# Altium schematic sheet audio out.SchDoc("audio out")
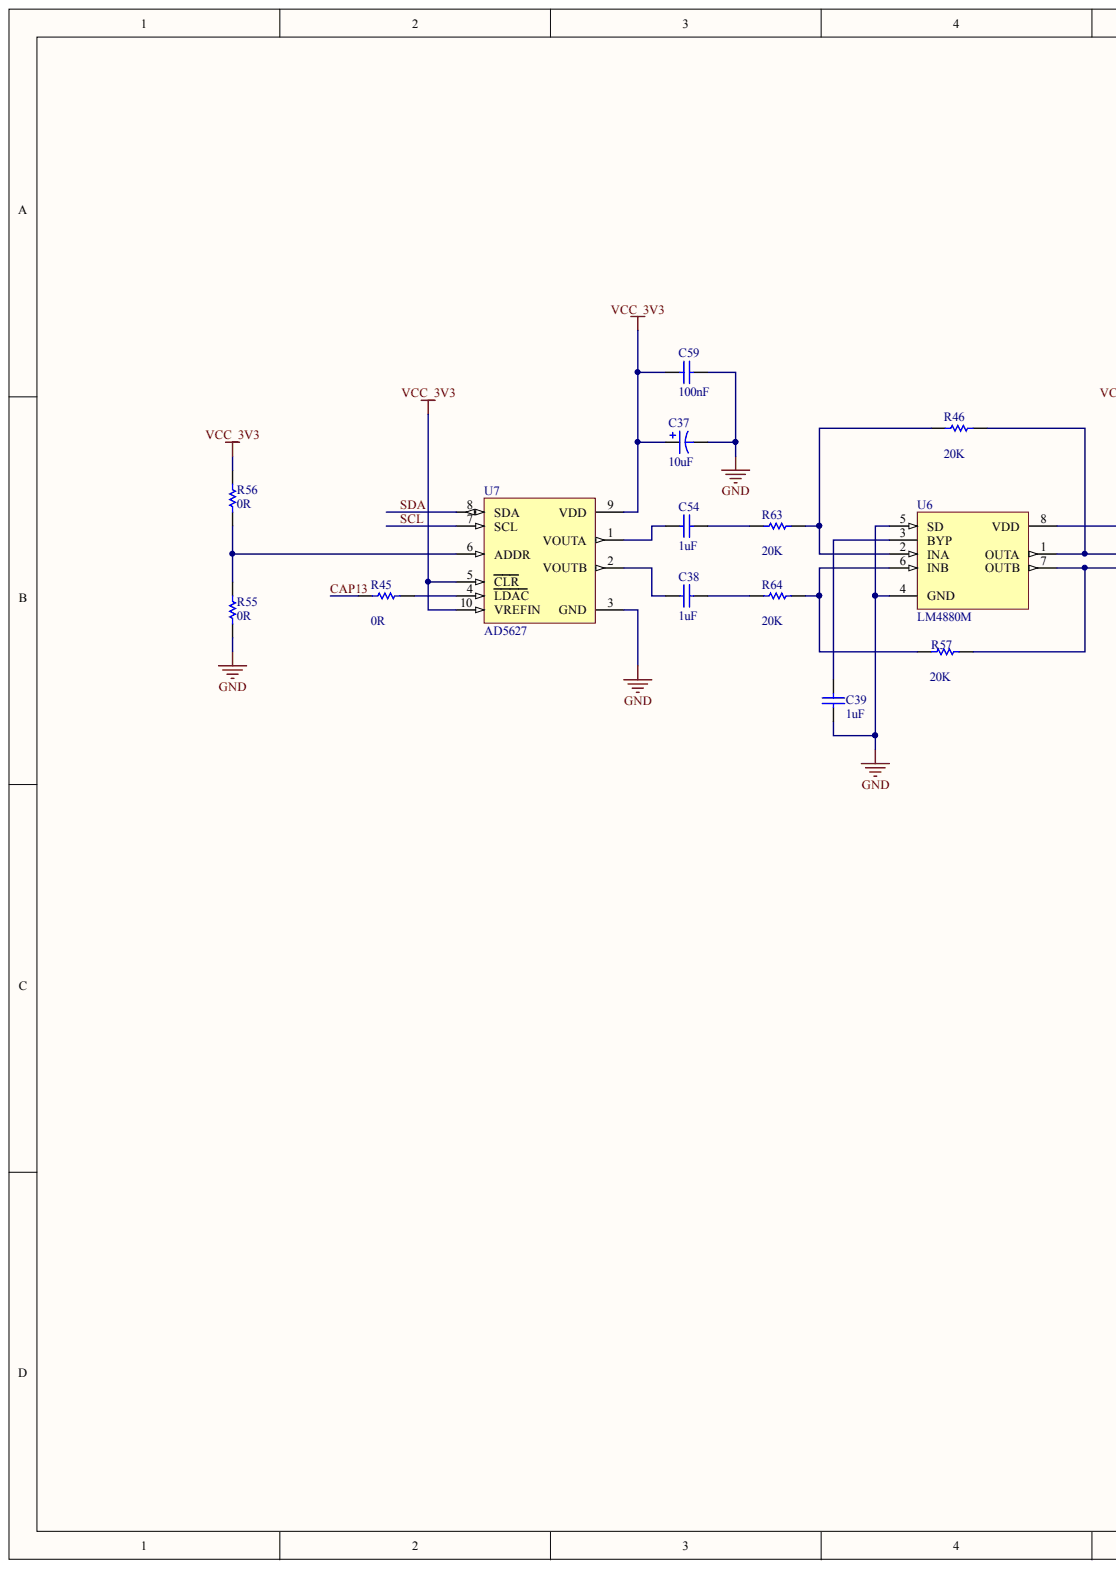
[diagram p0: audio out.SchDoc, left]
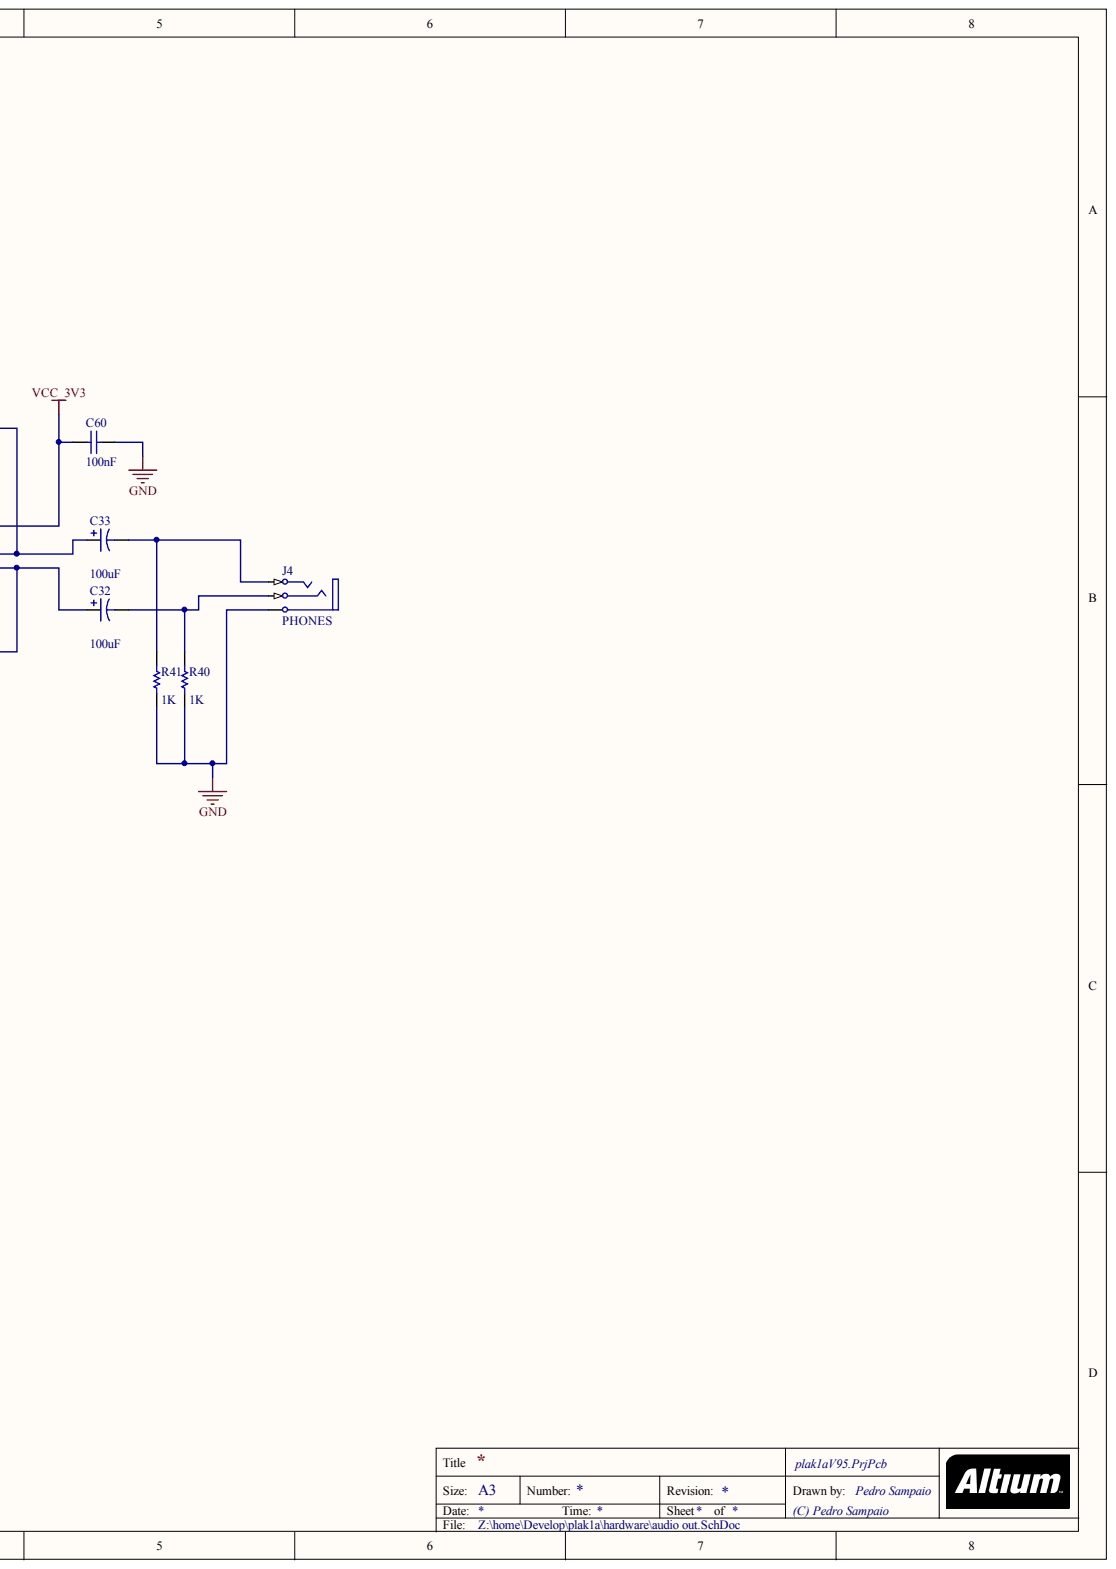
[diagram p1: audio out.SchDoc, right]

## Parts
C32  value 100uF  [D]  (hidden comment: T492D)
C33  value 100uF  [D]  (hidden comment: T492D)
C37  value 10uF  [B]  (hidden comment: T491B)
C38  value 1uF  [2012[0805]]  (hidden comment: 8805628)
C39  value 1uF  [2012[0805]]  (hidden comment: 8805628)
C54  value 1uF  [2012[0805]]  (hidden comment: 8805628)
C59  value 100nF  [0603]  (hidden comment: C0603)
C60  value 100nF  [0603]  (hidden comment: C0603)
J4  PHONES  [JACK STEREO]
R40  value 1K  [3216[1206]]  (hidden comment: Res Semi)
R41  value 1K  [3216[1206]]  (hidden comment: Res Semi)
R45  value 0R  [3216[1206]]  (hidden comment: Res Semi)
R46  value 20K  [3216[1206]]  (hidden comment: Res Semi)
R55  value 0R  [3216[1206]]  (hidden comment: Res Semi)
R56  value 0R  [3216[1206]]  (hidden comment: Res Semi)
R57  value 20K  [3216[1206]]  (hidden comment: Res Semi)
R63  value 20K  [3216[1206]]  (hidden comment: Res Semi)
R64  value 20K  [3216[1206]]  (hidden comment: Res Semi)
U6  LM4880M  [M08A_L]
U7  AD5627  [RM-10]

## Nets
GND: C37.2 C39.2 C59.2 C60.2 J4.1 R40.1 R41.1 R55.1 U6.4(GND) U6.5(SD) U7.3(GND)
VCC_3V3: C37.1 C59.1 C60.1 R56.2 U6.8(VDD) U7.5(CLR) U7.9(VDD) U7.10(VREFIN)
CAP13: R45.1
SCL: U7.7(SCL)
SDA: U7.8(SDA)
NetC32_1: C32.1 R57.2 U6.7(OUTB)
NetC32_2: C32.2 J4.3 R40.2
NetC33_1: C33.1 R46.2 U6.1(OUTA)
NetC33_2: C33.2 J4.2 R41.2
NetC38_1: C38.1 R64.1
NetC38_2: C38.2 U7.2(VOUTB)
NetC39_1: C39.1 U6.3(BYP)
NetC54_1: C54.1 R63.1
NetC54_2: C54.2 U7.1(VOUTA)
NetR45_2: R45.2 U7.4(LDAC)
NetR46_1: R46.1 R63.2 U6.2(INA)
NetR55_2: R55.2 R56.1 U7.6(ADDR)
NetR57_1: R57.1 R64.2 U6.6(INB)

## Library data of the parts
C32 = T492D: Solid Tantalum Chip Capacitor, T492 Series - CWR11 Style Per Mil-PRF-55365/8; Code_JEITA: 7343-31; Component Kind: Standard; ComponentLink1Description: Manufacturer Link; ComponentLink1URL: http://www.kemet.com/; ComponentLink2Description: Datasheet; ComponentLink2URL: http://www.kemet.com/kemet/web/homepage/kechome.nsf/vapubfilesname/F3102TA.pdf/$file/F3102TA.pdf; DatasheetDocument: Mar-2001; Farnell: 1457492; LatestRevisionDate: 19-Mar-2004; LatestRevisionNote: Silkscreen dimensions re-sized.; Library Name: KEMET Chip Capacitor.IntLib; PackageDocument: Mar-2001; PackageReference: D; Published: 17-Jul-2002; Signal Integrity: T492D; Simulation: CAP; Publisher: Altium Limited
C33 = T492D: Solid Tantalum Chip Capacitor, T492 Series - CWR11 Style Per Mil-PRF-55365/8; Value: 100uF; Code_JEITA: 7343-31; Component Kind: Standard; ComponentLink1Description: Manufacturer Link; ComponentLink1URL: http://www.kemet.com/; ComponentLink2Description: Datasheet; ComponentLink2URL: http://www.kemet.com/kemet/web/homepage/kechome.nsf/vapubfilesname/F3102TA.pdf/$file/F3102TA.pdf; DatasheetDocument: Mar-2001; Farnell: 1457492; LatestRevisionDate: 19-Mar-2004; LatestRevisionNote: Silkscreen dimensions re-sized.; Library Name: KEMET Chip Capacitor.IntLib; PackageDocument: Mar-2001; PackageReference: D; Published: 17-Jul-2002; Signal Integrity: T492D; Simulation: CAP; Publisher: Altium Limited
C37 = T491B: Solid Tantalum Chip Capacitor, Standard T491 Series - Industrial Grade; Code_JEITA: 3528-21; Component Kind: Standard; ComponentLink1Description: Manufacturer Link; ComponentLink1URL: http://www.kemet.com/; ComponentLink2Description: Datasheet; ComponentLink2URL: http://www.kemet.com/kemet/web/homepage/kechome.nsf/vapubfilesname/F3102TA.pdf/$file/F3102TA.pdf; DatasheetDocument: Mar-2001; Farnell: 1301818; LatestRevisionDate: 19-Mar-2004; LatestRevisionNote: Silkscreen dimensions re-sized.; Library Name: KEMET Chip Capacitor.IntLib; PackageDocument: Mar-2001; PackageReference: B; Published: 17-Jul-2002; Signal Integrity: T491B; Simulation: CAP; Publisher: Altium Limited
C38 C39 C54 = C0805: Ceramic Chip Capacitor - Standard; Note: Footprint corresponds to dimensions for bulk cassette packaging. This case size has tightened tolerances.; Code_IPC: 2012[0805]; Code_JEITA: 0805; Component Kind: Standard; ComponentLink1Description: Manufacturer Link; ComponentLink1URL: http://www.kemet.com/; ComponentLink2Description: Datasheet; ComponentLink2URL: http://www.kemet.com/kemet/web/homepage/kechome.nsf/vapubfilesname/F3102TA.pdf/$file/F3102TA.pdf; DatasheetDocument: Mar-2001; Farnell: 8805628; LatestRevisionDate: 19-Mar-2004; LatestRevisionNote: Silkscreen size reduced.; Library Name: KEMET Chip Capacitor.IntLib; PackageDocument: Mar-2001; PackageReference: 0805; Published: 17-Jul-2002; Signal Integrity: C0805; Simulation: CAP; Publisher: Altium Limited
C59 C60 = C0603: Ceramic Chip Capacitor - Standard; Note: Footprint corresponds to dimensions for bulk cassette packaging. This case size has tightened tolerances.; Code_IPC: 1608 [0603]; Code_JEITA: 0603; Component Kind: Standard; ComponentLink1Description: Manufacturer Link; ComponentLink1URL: http://www.kemet.com/; ComponentLink2Description: Datasheet; ComponentLink2URL: http://www.kemet.com/kemet/web/homepage/kechome.nsf/vapubfilesname/F3102TA.pdf/$file/F3102TA.pdf; DatasheetDocument: Mar-2001; Farnell: 1301716; LatestRevisionDate: 19-Mar-2004; LatestRevisionNote: Silkscreen size reduced.; Library Name: KEMET Chip Capacitor.IntLib; PackageDocument: Mar-2001; PackageReference: 0603; Published: 17-Jul-2002; Signal Integrity: C0603; Simulation: CAP; Publisher: Altium Limited
J4 = Jack 3 Power: Jack Socket, 1/4" [6.5mm], Thru-Hole, Vertical, 3-Conductor Open Circuit (Non-Normalling); DrillAndSlotSize: Corresponds to nominal value.; PackageDocument: 2003; PCB_Layout: Complies with manufacturer's recommendation.; Component Kind: Standard; ComponentLink1Description: Manufacturer Link; ComponentLink1URL: http://www.cliffuk.co.uk/; DatasheetDocument: 2003; Farnell: 1243244; LatestRevisionDate: 15-Jul-2004; LatestRevisionNote: Component Replaced.; Library Name: Componentes.IntLib; PackageReference: S1VS_SSS; Published: 15-Jul-2004; Signal Integrity: JACK Socket; Publisher: Altium Limited
R40 R41 R45 R46 R55-R57 R63 R64 = Res Semi: Semiconductor Resistor; Component Kind: Standard; LatestRevisionDate: 17-Jul-2002; LatestRevisionNote: Re-released for DXP Platform.; Library Name: Miscellaneous Devices.IntLib; PackageReference: AXIAL-0.5; Published: 8-Jun-2000; Signal Integrity: Res Semi; Simulation: RES; Publisher: Altium Limited
  R40 R41: Farnell: 9234004
  R45 R55 R56: Farnell: 9240489
  R46 R57 R63 R64: Farnell: 1469993
U6 = LM4880M: Dual 250mW Audio Amplifier with Shutdown Mode; Code_IPC: SOIC127P600-8; Code_JEDEC: MS-012-AA; Component Kind: Standard; ComponentLink1Description: Manufacturer Link; ComponentLink1URL: http://www.national.com/; ComponentLink2Description: Datasheet; ComponentLink2URL: http://www.national.com/ds.cgi/LM/LM4880.pdf; ComponentLink3Description: URL Link1; ComponentLink3URL: http://www.national.com/pf/LM/LM4880.html; DatasheetVersion: Nov-1995; Farnell: 9493921; LatestRevisionDate: 13-Apr-2006; LatestRevisionNote: IPC-7351 Footprint Added.; Library Name: NSC Audio.IntLib; PackageDescription: 8-Pin Small Outline Integrated Circuit 1.27 mm Pitch; PackageReference: M08A; PackageVersion: Sep-1997; PCB3D: M08A; Published: 15-Apr-2002; Publisher: Altium Limited
U7 = AD5627: Dual 12/14/16-Bit nanoDAC I2C Interface; Component Kind: Standard; Library Name: Componentes.IntLib
